# Altium schematic sheet MooresGlobe r2a.SchDoc
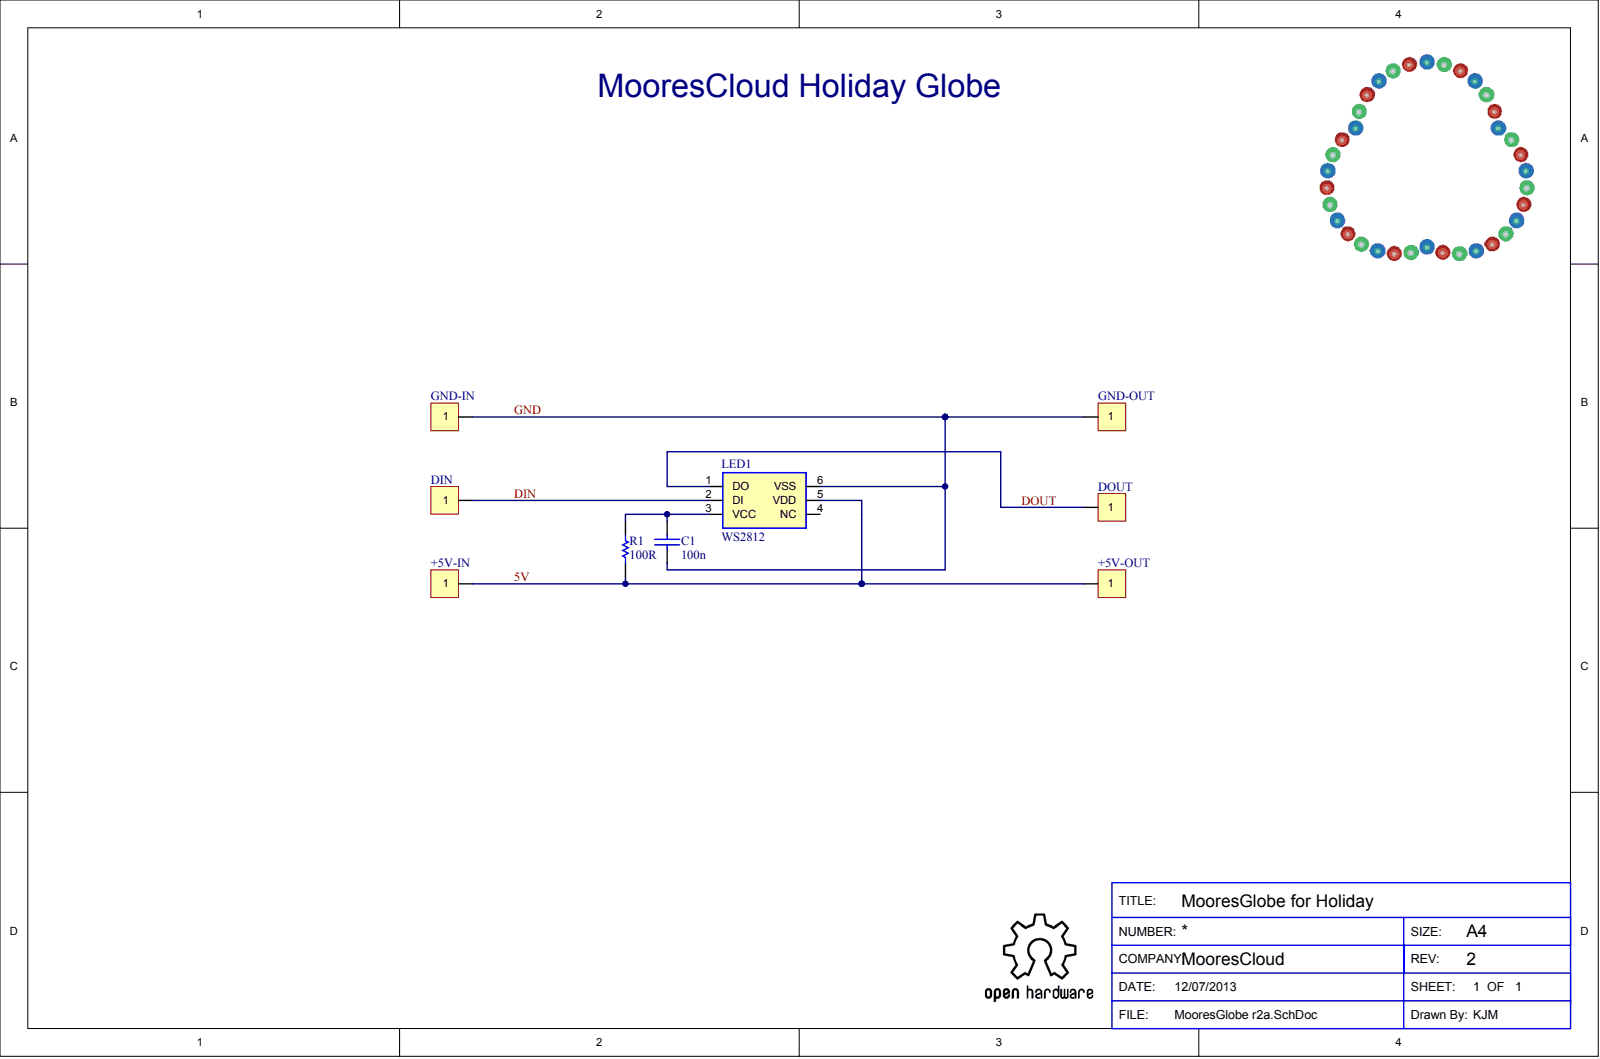
[diagram p0: MooresGlobe r2a.SchDoc]

## Parts
+5V-IN  +5V
+5V-OUT  +5V
C1  100n
DIN  DIN
DOUT  DOUT
GND-IN  GND
GND-OUT  GND
LED1  WS2812
R1  100R

## Nets
5V: +5V-IN.1 +5V-OUT.1 LED1.5(VDD) R1.1
DIN: DIN.1 LED1.2(DI)
DOUT: DOUT.1 LED1.1(DO)
GND: C1.2 GND-IN.1 GND-OUT.1 LED1.6(VSS)
NetC1_1: C1.1 LED1.3(VCC) R1.2

Not connected: LED1 4(NC)

## Library data of the parts
C1 = (no symbol name): 1.Manufacturer: Yageo; 4.CatNo: 311-1343-2-ND; 5.Note: Ceramic; 2.MfgNo: CC0603ZRY5V9BB104; 9.UnitCost: 0.00206; 3.Supplier: DigiKey
LED1 = (no symbol name): 1.Manufacturer: WorldSemi; 4.CatNo: sc-WS2811-5050; 9.UnitCost: 0.15; 2.MfgNo: WS2812; 3.Supplier: Shenzhen Scott Electronics
R1 = (no symbol name): 1.Manufacturer: Yageo; 4.CatNo: 311-100GRTR-ND; 2.MfgNo: RC0603JR-07100RL; 9.UnitCost: 0.00092; 3.Supplier: DigiKey
